# Altium schematic sheet wls2-mpu6050.SchDoc(wls2-mpu6050)
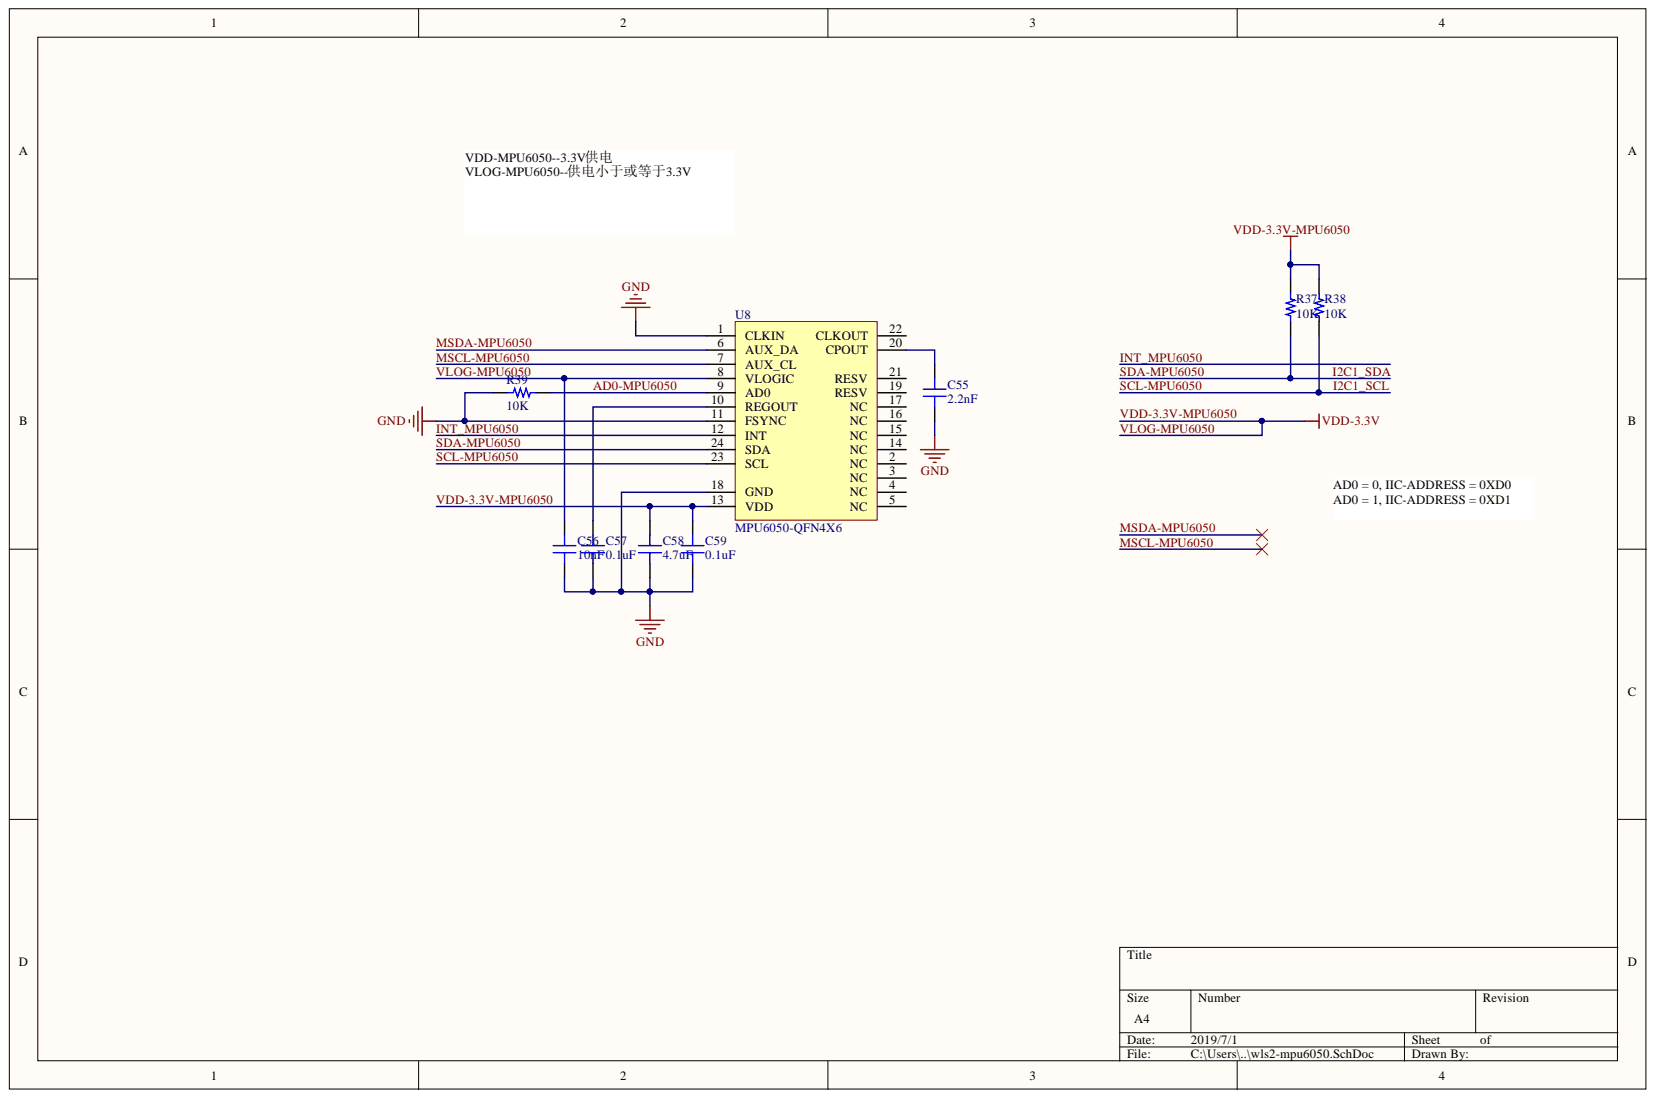
[diagram p0: wls2-mpu6050.SchDoc]

## Parts
C55  2.2nF  [RES 0402/1005]
C56  10nF  [RES 0402/1005]
C57  0.1uF  [RES 0402/1005]
C58  4.7uF  [RES 0402/1005]
C59  0.1uF  [RES 0402/1005]
R37  10K  [RES 0402/1005]
R38  10K  [RES 0402/1005]
R39  10K  [RES 0402/1005]
U8  MPU6050-QFN4X6  [QFN50P400X400X95_HS-25N]

## Nets
GND: C55.1 C56.1 C57.1 C58.1 C59.1 R39.2 U8.1(CLKIN) U8.11(FSYNC) U8.18(GND)
AD0-MPU6050: R39.1 U8.9(AD0)
I2C1_SCL: R38.1 U8.23(SCL)
I2C1_SDA: R37.1 U8.24(SDA)
INT_MPU6050: U8.12(INT)
MSCL-MPU6050: U8.7(AUX_CL)
MSDA-MPU6050: U8.6(AUX_DA)
VDD-3.3V-MPU6050: C56.2 C58.2 C59.2 R37.2 R38.2 U8.8(VLOGIC) U8.13(VDD)
NetC55_2: C55.2 U8.20(CPOUT)
NetC57_2: C57.2 U8.10(REGOUT)

Not connected: U8 2(NC) 3(NC) 4(NC) 5(NC) 14(NC) 15(NC) 16(NC) 17(NC) 19(RESV) 21(RESV) 22(CLKOUT)

## Library data of the parts
C55-C59 = Capacitor: SMT Capacitor; Component Kind: Standard; Library Name: Passives.SchLib
  C55: Value: 2.2nF
  C56: Value: 10nF
  C57 C59: Value: 0.1uF
  C58: Value: 4.7uF
R37-R39 = Resistor: SMT Resistor; Component Kind: Standard; Library Name: Passives.SchLib; Value: 10K
U8 = MPU6050: Component Kind: Standard; Description: MPU6050; Library Name: device_sch_lib.SchLib
